# Altium schematic sheet 4-Port_USB_HUB_SCH.SchDoc(4-Port_USB_HUB_SCH)
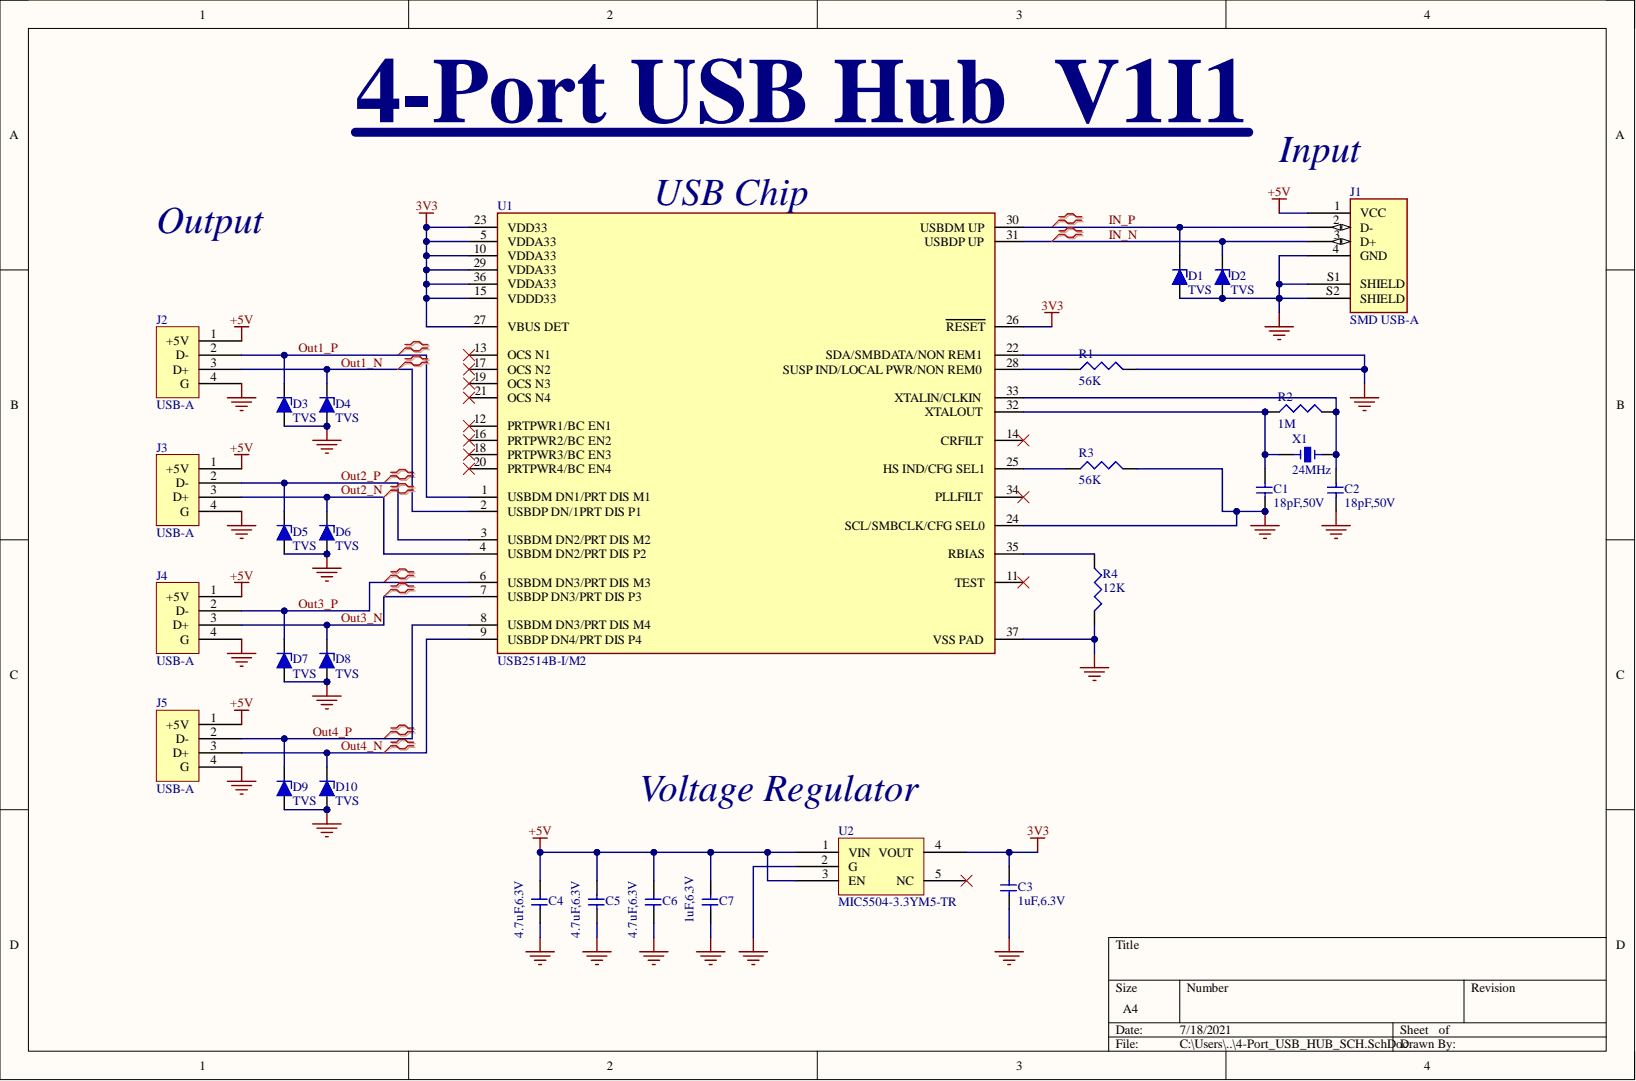
[diagram p0: 4-Port_USB_HUB_SCH.SchDoc]

## Parts
C1  18pF,50V  [18pF]
C2  18pF,50V  [18pF]
C3  1uF,6.3V  [1uF_CAP]
C4  4.7uF,6.3V  [4.7uF_CAP]
C5  4.7uF,6.3V  [4.7uF_CAP]
C6  4.7uF,6.3V  [4.7uF_CAP]
C7  1uF,6.3V  [1uF_CAP]
D1  TVS  [ESD Diode]
D2  TVS  [ESD Diode]
D3  TVS  [ESD Diode]
D4  TVS  [ESD Diode]
D5  TVS  [ESD Diode]
D6  TVS  [ESD Diode]
D7  TVS  [ESD Diode]
D8  TVS  [ESD Diode]
D9  TVS  [ESD Diode]
D10  TVS  [ESD Diode]
J1  SMD USB-A  Series: USB-A  [SMD USB-A]
J2  USB-A  [USB_Connector]
J3  USB-A  [USB_Connector]
J4  USB-A  [USB_Connector]
J5  USB-A  [USB_Connector]
R1  56K  [56K]
R2  1M  [1M Res]
R3  56K  [56K]
R4  12K  [1M Res]
U1  USB2514B-I/M2  Interface: USB  [IC_microchip]
U2  MIC5504-3.3YM5-TR  [Voltage Regulator]
X1  24MHz  [Crystal]

## Nets
3V3: C3.1 U1.5(VDDA33) U1.10(VDDA33) U1.15(VDDD33) U1.23(VDD33) U1.26(RESET) U1.27(VBUS_DET) U1.29(VDDA33) U1.36(VDDA33) U2.4(VOUT)
+5V: C4.1 C5.1 C6.1 C7.1 J1.1(VCC) J2.1(+5V) J3.1(+5V) J4.1(+5V) J5.1(+5V) U2.1(VIN) U2.3(EN)
GND: C1.2 C2.2 C3.2 C4.2 C5.2 C6.2 C7.2 D1.1 D2.1 D3.1 D4.1 D5.1 D6.1 D7.1 D8.1 D9.1 D10.1 J1.4(GND) J1.S1(SHIELD) J1.S2(SHIELD) J2.4(G) J3.4(G) J4.4(G) J5.4(G) R1.2 R3.2 R4.2 U1.22(SDA/SMBDATA/NON_REM1) U1.24(SCL/SMBCLK/CFG_SEL0) U1.37(VSS_PAD) U2.2(G)
IN_N: D2.2 J1.3(D+) U1.31(USBDP_UP)
IN_P: D1.2 J1.2(D-) U1.30(USBDM_UP)
Out1_N: D4.2 J2.3(D+) U1.2(USBDP_DN/1PRT_DIS_P1)
Out1_P: D3.2 J2.2(D-) U1.1(USBDM_DN1/PRT_DIS_M1)
Out2_N: D6.2 J3.3(D+) U1.4(USBDM_DN2/PRT_DIS_P2)
Out2_P: D5.2 J3.2(D-) U1.3(USBDM_DN2/PRT_DIS_M2)
Out3_N: D8.2 J4.3(D+) U1.7(USBDP_DN3/PRT_DIS_P3)
Out3_P: D7.2 J4.2(D-) U1.6(USBDM_DN3/PRT_DIS_M3)
Out4_N: D10.2 J5.3(D+) U1.9(USBDP_DN4/PRT_DIS_P4)
Out4_P: D9.2 J5.2(D-) U1.8(USBDM_DN3/PRT_DIS_M4)
NetC1_1: C1.1 R2.1 U1.32(XTALOUT) X1.1
NetC2_1: C2.1 R2.2 U1.33(XTALIN/CLKIN) X1.3
NetR1_1: R1.1 U1.28(SUSP_IND/LOCAL_PWR/NON_REM0)
NetR3_1: R3.1 U1.25(HS_IND/CFG_SEL1)
NetR4_1: R4.1 U1.35(RBIAS)

Not connected: U1 11(TEST) 12(PRTPWR1/BC_EN1) 13(OCS_N1) 14(CRFILT) 16(PRTPWR2/BC_EN2) 17(OCS_N2) 18(PRTPWR3/BC_EN3) 19(OCS_N3) 20(PRTPWR4/BC_EN4) 21(OCS_N4) 34(PLLFILT); U2 5(NC)

## Library data of the parts
C1 C2 = C0805C180J5GACTU: 18PF 50V 0805; Manufacturer: KEMET; Dielectric: C0G; Dielectric Material: Ceramic; Dissipation Factor: 0.1%; Temperature Coefficient: 30ppm/°C; Tolerance: 5%; Capacitance: 18pF; Case Code (Imperial): 0805; Case Code (Metric): 2012; Case/Package: 0805; Category: Ceramic Capacitors; Component Kind: Standard; ComponentLink1Description: https://datasheet.ciiva.com/22746/1769375-22746770.pdf?src-supplier=Element14; ComponentLink1URL: https://datasheet.ciiva.com/22746/1769375-22746770.pdf?src-supplier=Element14; ComponentLink2Description: https://datasheet.ciiva.com/26923/getdatasheetpartid-759702-26923980.pdf?src-supplier=Verical; ComponentLink2URL: https://datasheet.ciiva.com/26923/getdatasheetpartid-759702-26923980.pdf?src-supplier=Verical; ComponentLink3Description: https://datasheet.ciiva.com/26847/getdatasheetpartid-385668-26847862.pdf?src-supplier=Verical; ComponentLink3URL: https://datasheet.ciiva.com/26847/getdatasheetpartid-385668-26847862.pdf?src-supplier=Verical; Construction: Flat; Contact Material: Tin; Contact Plating: Tin,Matte; Depth: 1.25mm; Height: 0.78mm; Lead Free: Lead Free; Lead Pitch: 0.75mm; Length: 2mm; Manufacturer Part Number: C0805C180J5GACTU; Max Operating Temperature: 125°C; Min Operating Temperature: -55°C; Mount: Surface Mount,PCB; Number of Pins: 2; Package Quantity: 1; Packaging: Tape and Reel; Pricing 1: 1=0.13000, 10=0.09000, 50=0.05140, 100=0.04250, 500=0.02982, 1000=0.02468 (USD); Radiation Hardening: No; REACH SVHC: No SVHC; RoHS: Yes; RoHS Compliant: Yes; Series: C; Stock 1: 4285; Supplier 1: Digi-Key; Supplier Part Number 1: 399-1112-1-ND; Termination: SMD/SMT; Thickness: 0.035inch; Voltage: 50V; Voltage Rating: 50V; Voltage Rating (DC): 50V; Width: 1.2mm; Library Name: 4-Port_USB_HUB_SCHLib.SchLib
C3 C7 = C0402C105K9PACTU: 1uF 6.3V 0402; Manufacturer: KEMET; Dielectric: X5R; Dielectric Material: Ceramic; Tolerance: 10%; Capacitance: 1uF; Case Code (Imperial): 0402; Case/Package: 0402; Category: Ceramic Capacitors; Component Kind: Standard; ComponentLink1Description: https://datasheet.ciiva.com/28645/1789732-28645392.pdf?src-supplier=Element14; ComponentLink1URL: https://datasheet.ciiva.com/28645/1789732-28645392.pdf?src-supplier=Element14; ComponentLink2Description: https://datasheet.ciiva.com/7747/kem-c1006-x5r-smd-7747445.pdf?src-supplier=Element14; ComponentLink2URL: https://datasheet.ciiva.com/7747/kem-c1006-x5r-smd-7747445.pdf?src-supplier=Element14; ComponentLink3Description: https://datasheet.ciiva.com/7747/40889-7747623.pdf?src-supplier=Element14; ComponentLink3URL: https://datasheet.ciiva.com/7747/40889-7747623.pdf?src-supplier=Element14; ComponentLink4Description: https://datasheet.ciiva.com/18192/getdatasheetpartid-127800-18192427.pdf?src-supplier=Verical; ComponentLink4URL: https://datasheet.ciiva.com/18192/getdatasheetpartid-127800-18192427.pdf?src-supplier=Verical; Construction: Flat; Depth: 0.5mm; Height: 0.5mm; Lead Free: Lead Free; Lead Pitch: 0.3mm; Length: 1mm; Manufacturer Part Number: C0402C105K9PACTU; Max Operating Temperature: 85°C; Min Operating Temperature: -55°C; Mount: Surface Mount; Number of Pins: 2; Package Quantity: 1; Packaging: Tape and Reel; Pricing 1: 1=0.10000, 10=0.02400, 50=0.01320, 100=0.01070, 500=0.00764, 1000=0.00601, 2500=0.00546, 5000=0.00503 (USD); Radiation Hardening: No; REACH SVHC: No SVHC; RoHS: Yes; RoHS Compliant: Yes; Series: C; Stock 1: 252140; Supplier 1: Digi-Key; Supplier Part Number 1: 399-4873-1-ND; Termination: SMD/SMT; Thickness: 0.022inch; Voltage: 6.3V; Voltage Rating: 6.3V; Voltage Rating (DC): 6.3V; Width: 0.02inch; Library Name: 4-Port_USB_HUB_SCHLib.SchLib
C4-C6 = GRM155R60J475ME47D: 4.7uF 6.3V 0402; Manufacturer: Murata; Dielectric: X5R; Tolerance: 20%; Capacitance: 4.7uF; Case Code (Imperial): 0402; Case Code (Metric): 1005; Case/Package: 0402; Category: Ceramic Capacitors; Component Kind: Standard; ComponentLink1Description: https://datasheet.ciiva.com/6270/product-6270483.pdf?src-supplier=Digi-Key; ComponentLink1URL: https://datasheet.ciiva.com/6270/product-6270483.pdf?src-supplier=Digi-Key; ComponentLink2Description: https://datasheet.ciiva.com/6268/partnumbering-e-01-6268378.pdf?src-supplier=Digi-Key; ComponentLink2URL: https://datasheet.ciiva.com/6268/partnumbering-e-01-6268378.pdf?src-supplier=Digi-Key; ComponentLink3Description: https://datasheet.ciiva.com/46418/1769893-46418044.pdf?src-supplier=Element14; ComponentLink3URL: https://datasheet.ciiva.com/46418/1769893-46418044.pdf?src-supplier=Element14; ComponentLink4Description: https://datasheet.ciiva.com/46418/1769893-46418045.pdf?src-supplier=Element14; ComponentLink4URL: https://datasheet.ciiva.com/46418/1769893-46418045.pdf?src-supplier=Element14; ComponentLink5Description: https://datasheet.ciiva.com/6268/partnumbering-e-01-6268382.pdf?src-supplier=Digi-Key; ComponentLink5URL: https://datasheet.ciiva.com/6268/partnumbering-e-01-6268382.pdf?src-supplier=Digi-Key; Contact Plating: Tin; Depth: 0.5mm; Height: 0.5mm; Lead Free: Lead Free; Length: 1mm; Manufacturer Part Number: GRM155R60J475ME47D; Max Operating Temperature: 85°C; Min Operating Temperature: -55°C; Mount: Surface Mount; Package Quantity: 10000; Packaging: Cut Tape; Pricing 1: 50=0.07500, 500=0.02600, 2500=0.02500, 5000=0.02500, 10000=0.02300 (USD); REACH SVHC: No SVHC; RoHS: Yes; RoHS Compliant: Yes; Series: GRM; Stock 1: 42550; Supplier 1: RSComponents; Supplier Part Number 1: 8145230P; Termination: Standard; Thickness: 0.022inch; Voltage: 6.3V; Voltage Rating: 6.3V; Voltage Rating (DC): 6.3V; Weight: 0.000212oz; Width: 0.5mm; Library Name: 4-Port_USB_HUB_SCHLib.SchLib
D1-D10 = ESD9B3.3ST5G: TVS Diode; Manufacturer: ON Semiconductor; Breakdown Voltage: 5V; Capacitance: 15pF; Clamping Voltage: 10.5V; Diode Type: ZENER; Direction: Bidirectional; Element Configuration: Single; ESD Protection: Yes; Leakage Current: 1uA; Max Power Dissipation: 300mW; Number of Bidirectional Channels: 1; Operating Supply Voltage: 3.3V; Peak Pulse Current: 2A; Power Line Protection: No; Test Current: 1mA; Case/Package: SOD-923-2; Category: ESD and Circuit Protection ICs; Component Kind: Standard; ComponentLink1Description: https://datasheet.ciiva.com/339/esd9b-d-339712.pdf?src-supplier=Digi-Key; ComponentLink1URL: https://datasheet.ciiva.com/339/esd9b-d-339712.pdf?src-supplier=Digi-Key; ComponentLink2Description: https://datasheet.ciiva.com/339/esd9b-d-339712.pdf?src-supplier=Mouser; ComponentLink2URL: https://datasheet.ciiva.com/339/esd9b-d-339712.pdf?src-supplier=Mouser; ComponentLink3Description: https://datasheet.ciiva.com/25958/esd9b-d-25958117.pdf?src-supplier=Avnet+Europe; ComponentLink3URL: https://datasheet.ciiva.com/25958/esd9b-d-25958117.pdf?src-supplier=Avnet+Europe; ComponentLink4Description: https://datasheet.ciiva.com/339/esd9b-d-339713.pdf?src-supplier=Digi-Key; ComponentLink4URL: https://datasheet.ciiva.com/339/esd9b-d-339713.pdf?src-supplier=Digi-Key; ComponentLink5Description: https://datasheet.ciiva.com/339/esd9b-d-339714.pdf?src-supplier=Digi-Key; ComponentLink5URL: https://datasheet.ciiva.com/339/esd9b-d-339714.pdf?src-supplier=Digi-Key; ComponentLink6Description: https://datasheet.ciiva.com/22642/1596367-22642026.pdf?src-supplier=Element14; ComponentLink6URL: https://datasheet.ciiva.com/22642/1596367-22642026.pdf?src-supplier=Element14; ComponentLink7Description: https://datasheet.ciiva.com/26917/getdatasheetpartid-292713-26917946.pdf?src-supplier=Verical; ComponentLink7URL: https://datasheet.ciiva.com/26917/getdatasheetpartid-292713-26917946.pdf?src-supplier=Verical; ComponentLink8Description: https://datasheet.ciiva.com/22642/1596367-22642028.pdf?src-supplier=Element14; ComponentLink8URL: https://datasheet.ciiva.com/22642/1596367-22642028.pdf?src-supplier=Element14; ComponentLink9Description: https://datasheet.ciiva.com/11193/esd9b-d-11193999.pdf?src-supplier=Avnet; ComponentLink9URL: https://datasheet.ciiva.com/11193/esd9b-d-11193999.pdf?src-supplier=Avnet; Contact Plating: Tin,Matte; Depth: 0.6mm; Halogen Free: Halogen Free; Height: 0.4mm; Lead Free: Lead Free; Length: 0.8mm; Manufacturer Part Number: ESD9B3.3ST5G; Max Operating Temperature: 150°C; Max Reverse Leakage Current: 1uA; Min Breakdown Voltage: 5V; Min Operating Temperature: -55°C; Number of Channels: 1; Number of Elements: 1; Number of Lines: 1; Number of Pins: 2; Package Quantity: 1; Packaging: Tape and Reel; Peak Pulse Power: 300mW; Polarity: Bidirectional; Pricing 1: 1=0.23000, 10=0.18500, 100=0.09790, 500=0.06440, 1000=0.04379, 2000=0.03950 (USD); Radiation Hardening: No; REACH SVHC: No SVHC; Resistor Type: Zener; Reverse Breakdown Voltage: 5V; Reverse Standoff Voltage: 3.3V; RoHS: Yes; RoHS Compliant: Yes; Series: Automotive, AEC-Q101; Stock 1: 6111; Supplier 1: Digi-Key; Supplier Part Number 1: ESD9B3.3ST5GOSCT-ND; Termination: SMD/SMT; Width: 0.65mm; Working Voltage: 3.3V; Library Name: 4-Port_USB_HUB_SCHLib.SchLib
J1 = USB-A-S-S-B-SM2: USB - A USB 2.0 Receptacle Connector 4 Position Surface Mount, Right Angle, Horizontal; Manufacturer: Samtec; Max Voltage Rating (AC): 30V; Number of Contacts: 4; Number of Ports: 1; Category: Connectors; Component Kind: Standard; ComponentLink1Description: https://datasheet.ciiva.com/851/usb-a-851788.pdf?src-supplier=Digi-Key; ComponentLink1URL: https://datasheet.ciiva.com/851/usb-a-851788.pdf?src-supplier=Digi-Key; Connector Type: USB; Contact Material: Bronze; Contact Plating: Gold; Depth: 14.8mm; Gender: Receptacle; Housing Material: Thermoplastic; Length: 16.4mm; Library Name: 4-Port_USB_HUB_SCHLib.SchLib; Manufacturer Part Number: USB-A-S-S-B-SM2; Max Operating Temperature: 85°C; Min Operating Temperature: -50°C; Mount: Surface Mount,Horizontal; Number of Terminals/Pins: 4; Orientation: Right Angle; Package Quantity: 1; Packaging: Tray; Pricing 1: 1=2.03000, 10=1.84300, 25=1.73040, 40=1.65525, 80=1.58000, 230=1.42952, 440=1.31668, 945=1.12858, 2400=1.05335 (USD); Radiation Hardening: No; RoHS: Yes; RoHS Compliant: Yes; Stock 1: 1137; Supplier 1: Digi-Key; Supplier Part Number 1: SAM10864-ND; Termination: Solder
J2-J5 = KUSBVX-AS1N-B30: Conn USB SKT 4 POS Solder ST Thru-Hole 4 Terminal 1 Port; Manufacturer: Kycon; Connector Type: USB,Receptacle; Current Rating: 1A; Gender: Female; Insulation Resistance: 1GR; Number of Contacts: 4; Number of Ports: 1; Category: USB Connectors; Color: Black; Component Kind: Standard; ComponentLink1Description: https://datasheet.ciiva.com/16668/kusbvx-as1n-xxx-16668448.pdf?src-supplier=Avnet+Europe; ComponentLink1URL: https://datasheet.ciiva.com/16668/kusbvx-as1n-xxx-16668448.pdf?src-supplier=Avnet+Europe; ComponentLink2Description: https://datasheet.ciiva.com/4599/kusbvx-334767-4599050.pdf?src-supplier=Mouser; ComponentLink2URL: https://datasheet.ciiva.com/4599/kusbvx-334767-4599050.pdf?src-supplier=Mouser; ComponentLink3Description: https://datasheet.ciiva.com/3095/kusbvx-as1n-xxx-3095637.pdf?src-supplier=Avnet; ComponentLink3URL: https://datasheet.ciiva.com/3095/kusbvx-as1n-xxx-3095637.pdf?src-supplier=Avnet; Contact Material: Bronze; Contact Plating: Gold; Flammability Rating: UL94 V-0; Housing Material: Thermoplastic; Insulation Color: Black; Library Name: 4-Port_USB_HUB_SCHLib.SchLib; Manufacturer Part Number: KUSBVX-AS1N-B30; Mount: Through Hole; Number of Positions: 4; Orientation: Straight; Package Quantity: 120; Packaging: Tray; Pricing 1: 1=1.23000, 10=1.08400, 25=1.02040, 40=0.97800, 80=0.93550, 230=0.85043, 440=0.76539, 945=0.68034, 2400=0.61655 (USD); Radiation Hardening: No; RoHS: Yes; RoHS Compliant: Yes; Series: KUSBVX; Stock 1: 953; Supplier 1: Digi-Key; Supplier Part Number 1: 2092-KUSBVX-AS1N-B30-ND; Termination: Solder
R1 R3 = RC0402JR-0756KL: Manufacturer: Yageo; Max Power Dissipation: 63mW; Resistance: 56kR; Resistance Tolerance: 5%; Temperature Coefficient: 100ppm/°C; Voltage Rating: 50V; Case Code (Imperial): 0402; Case Code (Metric): 1005; Case/Package: 0402; Category: Chip SMD Resistors; Component Kind: Standard; ComponentLink1Description: https://datasheet.ciiva.com/7850/1018594-7850229.pdf?src-supplier=Element14; ComponentLink1URL: https://datasheet.ciiva.com/7850/1018594-7850229.pdf?src-supplier=Element14; ComponentLink2Description: https://datasheet.ciiva.com/12577/pyu-rc0402-51-rohs-l-6-r-12577526.pdf?src-supplier=Mouser; ComponentLink2URL: https://datasheet.ciiva.com/12577/pyu-rc0402-51-rohs-l-6-r-12577526.pdf?src-supplier=Mouser; ComponentLink3Description: https://datasheet.ciiva.com/15189/getdatasheetpartid-251833-15189574.pdf?src-supplier=Verical; ComponentLink3URL: https://datasheet.ciiva.com/15189/getdatasheetpartid-251833-15189574.pdf?src-supplier=Verical; ComponentLink4Description: https://datasheet.ciiva.com/16742/pyu-rc0402-51-rohs-l-6-r-16742167.pdf?src-supplier=Future+Electronics; ComponentLink4URL: https://datasheet.ciiva.com/16742/pyu-rc0402-51-rohs-l-6-r-16742167.pdf?src-supplier=Future+Electronics; ComponentLink5Description: https://datasheet.ciiva.com/7983/1018594-7983592.pdf?src-supplier=Element14; ComponentLink5URL: https://datasheet.ciiva.com/7983/1018594-7983592.pdf?src-supplier=Element14; ComponentLink6Description: https://datasheet.ciiva.com/16862/pyu-rc0402-51-rohs-l-6-16862495.pdf?src-supplier=Avnet+Europe; ComponentLink6URL: https://datasheet.ciiva.com/16862/pyu-rc0402-51-rohs-l-6-16862495.pdf?src-supplier=Avnet+Europe; Construction: Rectangular; Contact Plating: Tin,Matte; Depth: 0.5mm; Description: 56K; Features: Moisture Resistant; Height: 0.35mm; Lead Free: Lead Free; Length: 1mm; Manufacturer Part Number: RC0402JR-0756KL; Max Operating Temperature: 155°C; Military Standard: Not; Min Operating Temperature: -55°C; Number of Terminations: 2; Packaging: Tape and Reel; Power Rating: 63mW; Pricing 1: 1=0.10000, 10=0.01300, 100=0.00540, 1000=0.00244, 2500=0.00212, 5000=0.00175 (USD); Radiation Hardening: No; REACH SVHC: Unknown; Resistor Type: Thick Film; RoHS: Yes; RoHS Compliant: Yes; Series: RC; Stock 1: 173524; Supplier 1: Digi-Key; Supplier Part Number 1: 311-56KJRCT-ND; Technology: THICK FILM; Termination: SMD/SMT; Tolerance: 5%; Weight: 2.3E-05oz; Width: 0.5mm; Working Voltage: 50V; Library Name: 4-Port_USB_HUB_SCHLib.SchLib
R2 = RC0402FR-071ML: 1M OHM; Manufacturer: Yageo; Max Power Dissipation: 63mW; Resistance: 1MR; Resistance Tolerance: 1%; Temperature Coefficient: 100ppm/°C; Voltage Rating: 50V; Case Code (Imperial): 0402; Case Code (Metric): 1005; Case/Package: 0402; Category: Chip SMD Resistors; Component Kind: Standard; ComponentLink1Description: https://datasheet.ciiva.com/11808/0900766b80c66297-11808125.pdf?src-supplier=RSComponents; ComponentLink1URL: https://datasheet.ciiva.com/11808/0900766b80c66297-11808125.pdf?src-supplier=RSComponents; ComponentLink2Description: https://datasheet.ciiva.com/46394/1018594-46394951.pdf?src-supplier=Element14; ComponentLink2URL: https://datasheet.ciiva.com/46394/1018594-46394951.pdf?src-supplier=Element14; ComponentLink3Description: https://datasheet.ciiva.com/16741/pyu-rc0402-51-rohs-l-6-r-16741081.pdf?src-supplier=Future+Electronics; ComponentLink3URL: https://datasheet.ciiva.com/16741/pyu-rc0402-51-rohs-l-6-r-16741081.pdf?src-supplier=Future+Electronics; ComponentLink4Description: https://datasheet.ciiva.com/7849/1018594-7849882.pdf?src-supplier=Element14; ComponentLink4URL: https://datasheet.ciiva.com/7849/1018594-7849882.pdf?src-supplier=Element14; ComponentLink5Description: https://datasheet.ciiva.com/7849/1018594-7849883.pdf?src-supplier=Element14; ComponentLink5URL: https://datasheet.ciiva.com/7849/1018594-7849883.pdf?src-supplier=Element14; Construction: Rectangular; Depth: 0.5mm; Features: Moisture Resistant; Height: 0.32mm; Lead Free: Lead Free; Length: 1mm; Manufacturer Part Number: RC0402FR-071ML; Max Operating Temperature: 155°C; Military Standard: Not; Min Operating Temperature: -55°C; Number of Terminations: 2; Packaging: Tape and Reel; Power Rating: 63mW; Pricing 1: 1=0.10000, 10=0.01600, 100=0.00660, 1000=0.00299, 2500=0.00259, 5000=0.00214 (USD); REACH SVHC: Unknown; Resistor Type: Thick Film; RoHS: Yes; RoHS Compliant: Yes; Series: RC; Size Code: 0402; Stock 1: 3696366; Supplier 1: Digi-Key; Supplier Part Number 1: 311-1.00MLRCT-ND; Technology: THICK FILM; Termination: SMD/SMT; Tolerance: 1%; Library Name: 4-Port_USB_HUB_SCHLib.SchLib
R4 = RC0402JR-0712KL: 12K OHM; Manufacturer: Yageo; Max Power Dissipation: 63mW; Resistance: 12kR; Resistance Tolerance: 5%; Temperature Coefficient: 100ppm/°C; Voltage Rating: 50V; Case Code (Imperial): 0402; Case Code (Metric): 1005; Case/Package: 0402; Category: Chip SMD Resistors; Component Kind: Standard; ComponentLink1Description: https://datasheet.ciiva.com/2452/pyu-r-int-thick-7-2452758.pdf?src-supplier=Digi-Key; ComponentLink1URL: https://datasheet.ciiva.com/2452/pyu-r-int-thick-7-2452758.pdf?src-supplier=Digi-Key; ComponentLink2Description: https://datasheet.ciiva.com/6168/1018594-6168998.pdf?src-supplier=Farnell; ComponentLink2URL: https://datasheet.ciiva.com/6168/1018594-6168998.pdf?src-supplier=Farnell; ComponentLink3Description: https://datasheet.ciiva.com/2452/pyu-r-int-thick-7-2452759.pdf?src-supplier=Digi-Key; ComponentLink3URL: https://datasheet.ciiva.com/2452/pyu-r-int-thick-7-2452759.pdf?src-supplier=Digi-Key; ComponentLink4Description: https://datasheet.ciiva.com/2452/pyu-r-int-thick-7-2452760.pdf?src-supplier=Digi-Key; ComponentLink4URL: https://datasheet.ciiva.com/2452/pyu-r-int-thick-7-2452760.pdf?src-supplier=Digi-Key; ComponentLink5Description: https://datasheet.ciiva.com/7983/1018594-7983548.pdf?src-supplier=Element14; ComponentLink5URL: https://datasheet.ciiva.com/7983/1018594-7983548.pdf?src-supplier=Element14; ComponentLink6Description: https://datasheet.ciiva.com/5558/pyu-rc0402-51-rohs-l-6-r-5558094.pdf?src-supplier=Mouser; ComponentLink6URL: https://datasheet.ciiva.com/5558/pyu-rc0402-51-rohs-l-6-r-5558094.pdf?src-supplier=Mouser; Construction: Rectangular; Depth: 0.5mm; Features: Moisture Resistant; Height: 0.016inch; Lead Free: Lead Free; Length: 1mm; Manufacturer Part Number: RC0402JR-0712KL; Max Operating Temperature: 155°C; Military Standard: Not; Min Operating Temperature: -55°C; Number of Pins: 2; Number of Terminations: 2; Package Quantity: 1; Packaging: Tape and Reel; Power Rating: 63mW; Pricing 1: 1=0.10000, 10=0.01300, 100=0.00540, 1000=0.00244, 2500=0.00212, 5000=0.00175 (USD); REACH SVHC: No SVHC; Resistor Type: Thick Film; RoHS: Yes; RoHS Compliant: Yes; Series: RC; Size Code: 0402; Stock 1: 42769; Supplier 1: Digi-Key; Supplier Part Number 1: 311-12KJRCT-ND; Termination: SMD/SMT; Tolerance: 5%; Voltage Rating (DC): 50V; Width: 0.02inch; Library Name: 4-Port_USB_HUB_SCHLib.SchLib
U1 = USB2514B-I/M2: USB2514B-I/M2, USB Interface, USB Hub Controller, USB 2.0, 3 V, 3.6 V, SQFN, 36 Pins; Manufacturer: Microchip; Data Rate: 480Mbps; Max Supply Voltage: 3.6V; Min Supply Voltage: 3V; Number of Ports: 4; Operating Supply Current: 70mA; USB Standard: USB 2.0; Category: Integrated Circuits (ICs); Component Kind: Standard; ComponentLink1Description: http://www.farnell.com/datasheets/2032129.pdf?src-supplier=Farnell; ComponentLink1URL: http://www.farnell.com/datasheets/2032129.pdf?src-supplier=Farnell; ComponentLink2Description: http://www.farnell.com/datasheets/2032129.pdf?src-supplier=Element14; ComponentLink2URL: http://www.farnell.com/datasheets/2032129.pdf?src-supplier=Element14; ComponentLink3Description: http://www.microchip.com/mymicrochip/filehandler.aspx?ddocname=en562310&src-supplier=Digi-Key; ComponentLink3URL: http://www.microchip.com/mymicrochip/filehandler.aspx?ddocname=en562310&src-supplier=Digi-Key; Lead Free: Lead Free; Library Name: 4-Port_USB_HUB_SCHLib.SchLib; Manufacturer Part Number: USB2514B-I/M2; Max Operating Temperature: 85°C; Min Operating Temperature: -40°C; Number of Pins: 36; Packaging: Tray; Pricing 1: 1=3.55000, 10=3.29000, 25=3.02000 (USD); REACH SVHC: No SVHC; RoHS: Yes; RoHS Compliant: Yes; Supplier 1: Newark; Supplier Part Number 1: 55Y4214
U2 = MIC5504-3.3YM5-TR: MIC5504-3.3YM5-TR - LDO VOLT REG, 0.3A, 3.3V, SOT-23-5; Manufacturer: Microchip; Accuracy: 3%; Dropout Voltage: 380mV; Max Input Voltage: 5.5V; Max Output Current: 300mA; Min Input Voltage: 2.5V; Number of Outputs: 1; Operating Supply Current: 42uA; Output Type: Fixed; Power Supply Rejection Ratio (PSRR): 60dB; Quiescent Current: 38uA; Case/Package: SOT-23-5; Category: Voltage Regulators - Linear; Component Kind: Standard; ComponentLink1Description: https://datasheet.ciiva.com/46425/1856860-46425639.pdf?src-supplier=Element14; ComponentLink1URL: https://datasheet.ciiva.com/46425/1856860-46425639.pdf?src-supplier=Element14; Height: 1.1mm; Length: 2.9mm; Manufacturer Part Number: MIC5504-3.3YM5-TR; Max Operating Temperature: 125°C; Min Operating Temperature: -40°C; Mount: Surface Mount; Nominal Output Voltage: 3.3V; Number of Pins: 5; Output Current: 300mA; Output Voltage: 3.3V; Packaging: Tape and Reel; Pricing 1: 1=0.13000, 25=0.09000, 100=0.08000 (USD); REACH SVHC: No SVHC; RoHS: Yes; RoHS Compliant: No; Stock 1: 77294; Supplier 1: Digi-Key; Supplier Part Number 1: 576-4764-1-ND; Width: 1.6mm; Library Name: 4-Port_USB_HUB_SCHLib.SchLib
X1 = XRCGB24M000F0L00R0: Manufacturer: Murata; ESR (Equivalent Series Resistance): 150R; Frequency Stability: 50ppm; Frequency Tolerance: 100ppm; Load Capacitance: 6pF; Case/Package: SMD/SMT; Category: Crystals; Component Kind: Standard; ComponentLink1Description: https://datasheet.ciiva.com/3852/part15-3852009.pdf?src-supplier=Arrow+Electronics; ComponentLink1URL: https://datasheet.ciiva.com/3852/part15-3852009.pdf?src-supplier=Arrow+Electronics; ComponentLink2Description: https://datasheet.ciiva.com/852/p79e-852274.pdf?src-supplier=Digi-Key; ComponentLink2URL: https://datasheet.ciiva.com/852/p79e-852274.pdf?src-supplier=Digi-Key; ComponentLink3Description: https://datasheet.ciiva.com/852/p79e-852275.pdf?src-supplier=Digi-Key; ComponentLink3URL: https://datasheet.ciiva.com/852/p79e-852275.pdf?src-supplier=Digi-Key; ComponentLink4Description: https://datasheet.ciiva.com/852/p79e-852276.pdf?src-supplier=Digi-Key; ComponentLink4URL: https://datasheet.ciiva.com/852/p79e-852276.pdf?src-supplier=Digi-Key; ComponentLink5Description: https://datasheet.ciiva.com/1361/l0445e-1361516.pdf?src-supplier=Element14; ComponentLink5URL: https://datasheet.ciiva.com/1361/l0445e-1361516.pdf?src-supplier=Element14; ComponentLink6Description: https://datasheet.ciiva.com/22695/1911263-22695436.pdf?src-supplier=Element14; ComponentLink6URL: https://datasheet.ciiva.com/22695/1911263-22695436.pdf?src-supplier=Element14; Depth: 1.6mm; Description: 24MHZ; Frequency: 24MHz; Height: 0.028inch; Lead Free: Lead Free; Length: 2mm; Manufacturer Part Number: XRCGB24M000F0L00R0; Max Operating Temperature: 85°C; Min Operating Temperature: -30°C; Mount: Surface Mount; Number of Pins: 4; Operating Frequency: 24 MHz; Package Quantity: 3000; Packaging: Tape and Reel; Pricing 1: 1=0.32000, 10=0.26800, 50=0.24160, 100=0.21480, 500=0.20406, 1000=0.17184 (USD); REACH SVHC: No SVHC; RoHS: Yes; RoHS Compliant: Yes; Series: XRCGB; Series Resistance: 150R; Stock 1: 26986; Supplier 1: Digi-Key; Supplier Part Number 1: 490-5575-1-ND; Termination: SMD/SMT; Tolerance: 100ppm; Width: 1.6mm; Library Name: 4-Port_USB_HUB_SCHLib.SchLib
